# Altium schematic sheet Misc.SchDoc
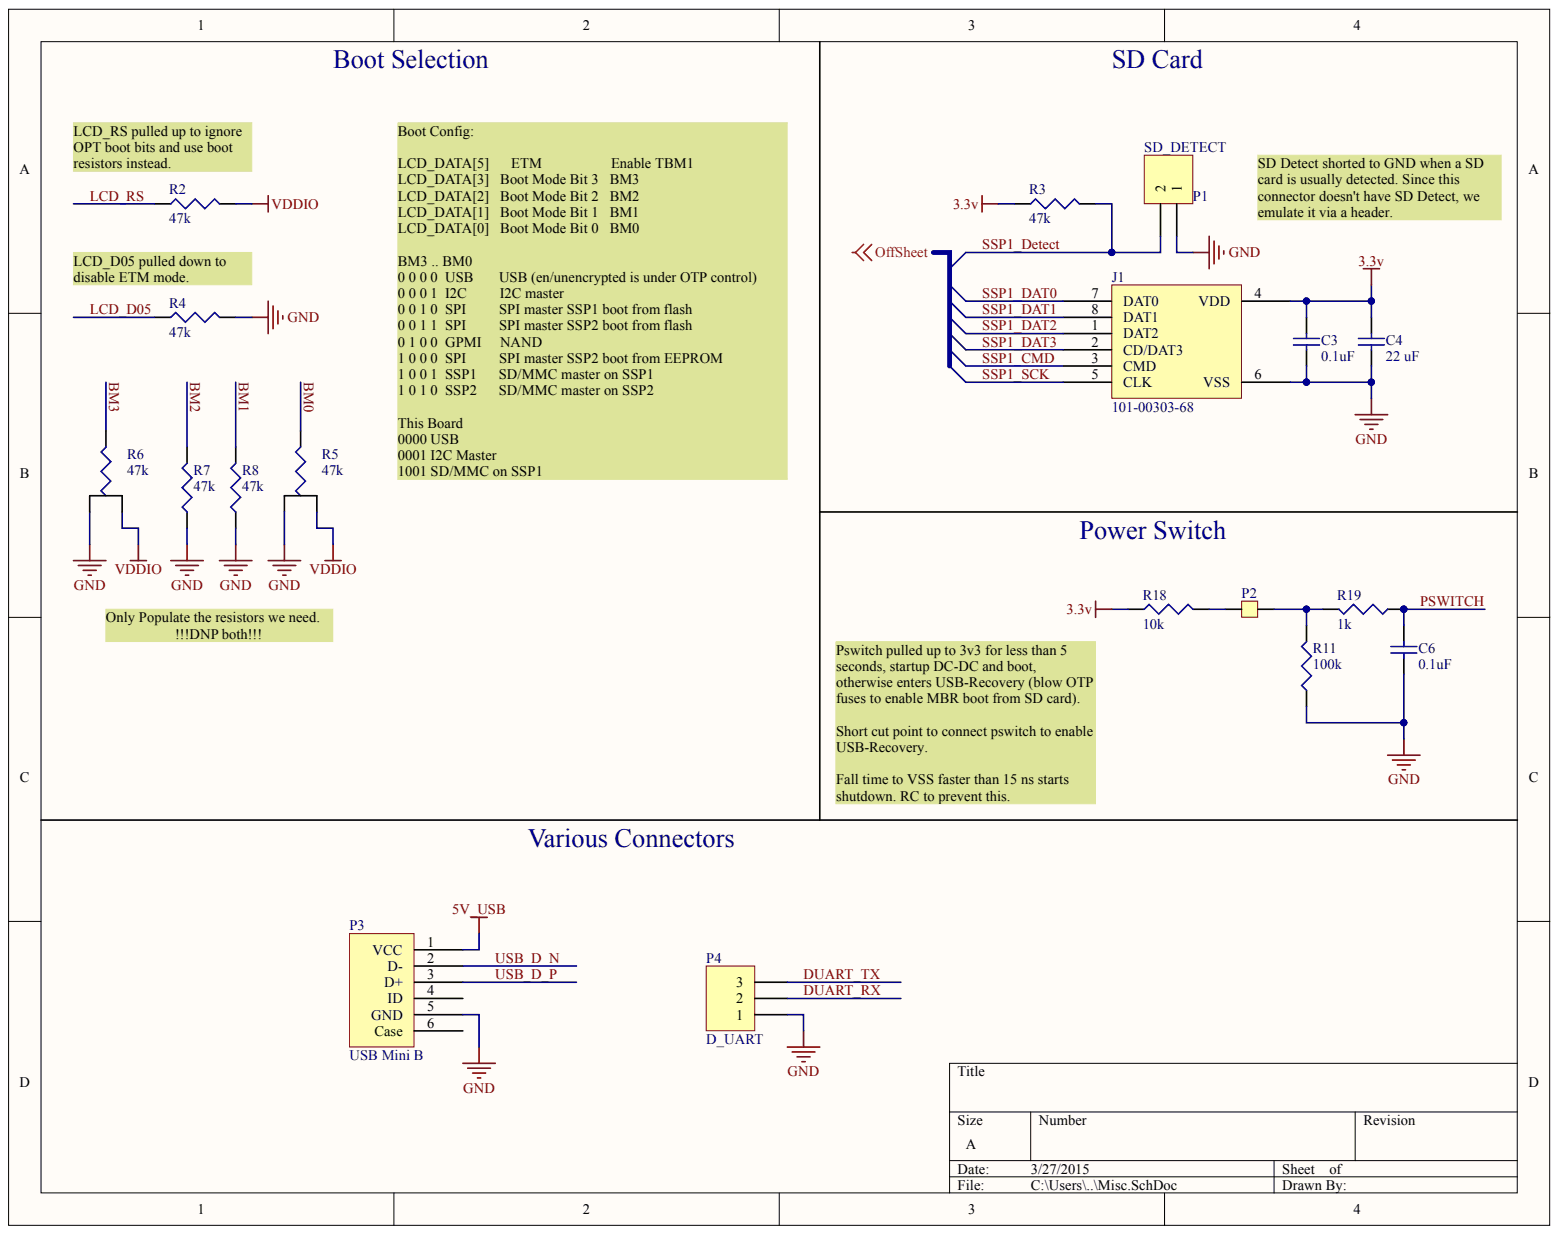
[diagram p0: Misc.SchDoc]

## Parts
C3  value 0.1uF  [0402]  (hidden comment: CL05B104KQ5NNNC)
C4  value 22 uF  [0805_GRM21]  (hidden comment: GRM21BR60J226ME39L)
C6  value 0.1uF  [0402]  (hidden comment: CL05B104KQ5NNNC)
J1  101-00303-68  [Micro_SD_Con]
P1  SD_DETECT  [HDR1X2]
P2  CutPointet 2x  [CutPoint-VIA-medium]  (value not drawn)
P3  USB Mini B  [USB Mini B]
P4  D_UART  [HDR1X3]
R2  value 47k  [J1-0603]  (hidden comment: MCR03ERTF4702)
R3  value 47k  [J1-0603]  (hidden comment: MCR03ERTF4702)
R4  value 47k  [J1-0603]  (hidden comment: MCR03ERTF4702)
R5  value 47k  [0603 Resistor Selectable]  (hidden comment: MCR03ERTF4702_SPDT)
R6  value 47k  [0603 Resistor Selectable]  (hidden comment: MCR03ERTF4702_SPDT)
R7  value 47k  [J1-0603]  (hidden comment: MCR03ERTF4702)
R8  value 47k  [J1-0603]  (hidden comment: MCR03ERTF4702)
R11  value 100k  [J1-0603]  (hidden comment: ERJ-3GEYJ104V)
R18  value 10k  [J1-0603]  (hidden comment: MCR03ERTJ103)
R19  value 1k  [J1-0603]  (hidden comment: MCR03ERTF1001)

## Nets
3.3V: C3.1 C4.2 J1.4(VDD) R3.1 R18.1
5V_USB: P3.1(VCC)
GND: C3.2 C4.1 C6.2 J1.6(VSS) P1.1 P3.5(GND) P4.1 R4.2 R5.1 R6.1 R7.1 R8.1 R11.1
VDDIO: R2.2 R5.3 R6.3
BM0: R5.2
BM1: R8.2
BM2: R7.2
BM3: R6.2
DUART_RX: P4.2
DUART_TX: P4.3
LCD_D05: R4.1
LCD_RS: R2.1
PSWITCH: C6.1 R19.1
SSP1_CMD: J1.3(CMD)
SSP1_DAT0: J1.7(DAT0)
SSP1_DAT1: J1.8(DAT1)
SSP1_DAT2: J1.1(DAT2)
SSP1_DAT3: J1.2(CD/DAT3)
SSP1_DETECT: P1.2 R3.2
SSP1_SCK: J1.5(CLK)
USB_D_N: P3.2(D-)
USB_D_P: P3.3(D+)
NetP2_1: P2.1 R18.2
NetP2_2: P2.2 R11.2 R19.2
N00191:
OFFSHEET:

Not connected: P3 4(ID) 6(Case)

## Library data of the parts
C3 C6 = CL05B104KQ5NNNC: Cap Ceramic 0.1uF 6.3V X7R 10% SMD 0402; Component Kind: Standard; LatestRevisionDate: 29-May-2009; LatestRevisionNote: IPC-7351 Footprint Added.; PackageDescription: Chip Capacitor; PackageReference: C1206; Published: 8-Jun-2000; Signal Integrity: Cap Semi; Simulation: CAP; Supplier 1: Arrow; Supplier 2: Digi-Key; Supplier Part Number 1: c0Ft5huTF1PnwzXLA59QPYxRs3E3aghew1LSAii7cAMZVywxIBNyoAQstvnyfZZ0; Supplier Part Number 2: 1276-1511-1-ND; Library Name: Linux_Board_SCHEM_LIB.SchLib; Publisher: Altium Limited
C4 = GRM21BR60J226ME39L: CAP CER 22UF 6.3V 20% X5R 0805; Component Kind: Standard; LatestRevisionDate: 29-May-2009; LatestRevisionNote: IPC-7351 Footprint Added.; PackageDescription: Chip Capacitor; PackageReference: C1206; Published: 8-Jun-2000; Signal Integrity: Cap Semi; Simulation: CAP; Supplier 1: Digi-Key; Supplier Part Number 1: 490-1719-1-ND; Library Name: Linux_Board_SCHEM_LIB.SchLib; Publisher: Altium Limited
J1 = 101-00303-68: CONN MICRO SD CARD HINGED TYPE; Component Kind: Standard; Supplier 1: Digi-Key; Supplier Part Number 1: 101-00303-68-1-ND; Library Name: Linux_Board_SCHEM_LIB.SchLib
P1 = Header 2: Header, 2-Pin; Component Kind: Standard; LatestRevisionDate: 17-Jul-2002; LatestRevisionNote: Re-released for DXP Platform.; Library Name: Miscellaneous Connectors.IntLib; Signal Integrity: Connector; Publisher: Altium Limited
P2 = CutPointet 2x: 2 net point that can be cut and soldered; Component Kind: Standard; LatestRevisionDate: 17-Jul-2002; LatestRevisionNote: Re-released for DXP Platform.; Signal Integrity: Connector; Library Name: Linux_Board_SCHEM_LIB.SchLib; Publisher: Altium Limited
P3 = USB Mini B: USB Mini B connector; Component Kind: Standard; Signal Integrity: Guessed Model; Library Name: Linux_Board_SCHEM_LIB.SchLib
P4 = Header 3: Header, 3-Pin; Component Kind: Standard; LatestRevisionDate: 17-Jul-2002; LatestRevisionNote: Re-released for DXP Platform.; Library Name: Miscellaneous Connectors.IntLib; Signal Integrity: Connector; Publisher: Altium Limited
R2-R4 R7 R8 = MCR03ERTF4702: RES SMD 47K OHM 1% 1/10W 0603; Component Kind: Standard; LatestRevisionDate: 29-May-2009; LatestRevisionNote: IPC-7351 Footprint Added.; PackageDescription: Chip Resistor; PackageReference: J1-0603; Published: 8-Jun-2000; Signal Integrity: Res; Simulation: RESISTOR; Supplier 1: Digi-Key; Supplier Part Number 1: RHM47.0KCFCT-ND; Library Name: Linux_Board_SCHEM_LIB.SchLib; Publisher: Altium Limited
R5 R6 = MCR03ERTF4702_SPDT: RES SMD 47K OHM 1% 1/10W 0603 selectable SPDT; Component Kind: Standard; LatestRevisionDate: 29-May-2009; LatestRevisionNote: IPC-7351 Footprint Added.; PackageDescription: Chip Resistor; PackageReference: J1-0603; Published: 8-Jun-2000; Signal Integrity: Res; Simulation: RESISTOR; Supplier 1: Digi-Key; Supplier Part Number 1: RHM47.0KCFCT-ND; Library Name: Linux_Board_SCHEM_LIB.SchLib; Publisher: Altium Limited
R11 = ERJ-3GEYJ104V: RES SMD 100K OHM 5% 1/10W 0603; Component Kind: Standard; LatestRevisionDate: 29-May-2009; LatestRevisionNote: IPC-7351 Footprint Added.; PackageDescription: Chip Resistor; PackageReference: J1-0603; Published: 8-Jun-2000; Signal Integrity: Res; Simulation: RESISTOR; Supplier 1: Digi-Key; Supplier Part Number 1: P100KGCT-ND; Library Name: Linux_Board_SCHEM_LIB.SchLib; Publisher: Altium Limited
R18 = MCR03ERTJ103: RES SMD 10K OHM 5% 1/10W 0603; Component Kind: Standard; LatestRevisionDate: 29-May-2009; LatestRevisionNote: IPC-7351 Footprint Added.; PackageDescription: Chip Resistor; PackageReference: J1-0603; Published: 8-Jun-2000; Signal Integrity: Res; Simulation: RESISTOR; Supplier 1: Digi-Key; Supplier Part Number 1: RHM10KCGCT-ND; Library Name: Linux_Board_SCHEM_LIB.SchLib; Publisher: Altium Limited
R19 = MCR03ERTF1001: RES SMD 1K OHM 1% 1/10W 0603; Component Kind: Standard; LatestRevisionDate: 29-May-2009; LatestRevisionNote: IPC-7351 Footprint Added.; PackageDescription: Chip Resistor; PackageReference: J1-0603; Published: 8-Jun-2000; Signal Integrity: Res; Simulation: RESISTOR; Supplier 1: Digi-Key; Supplier Part Number 1: P100KGCT-ND; Library Name: Linux_Board_SCHEM_LIB.SchLib; Publisher: Altium Limited
